# Altium schematic sheet BMS HY2120-CB.SchDoc
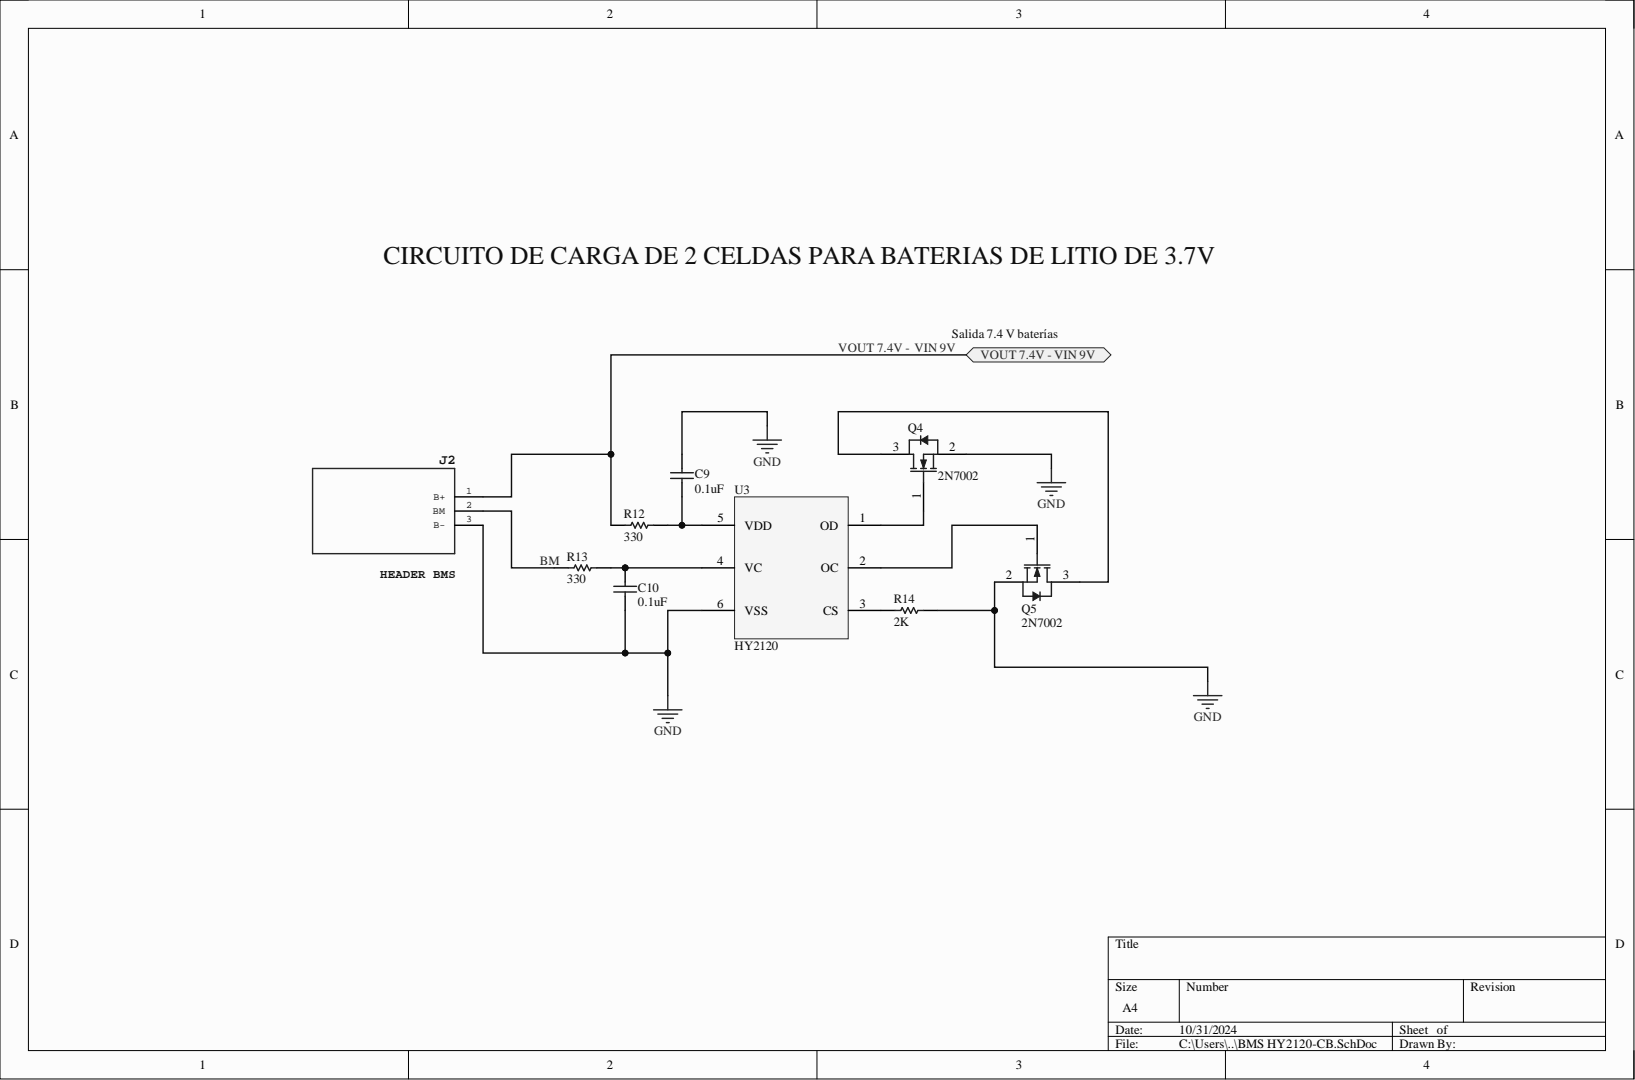
[diagram p0: BMS HY2120-CB.SchDoc]

## Parts
C9  value 0.1uF  [CC0805]  (hidden comment: Cap)
C10  value 0.1uF  [CC0805]  (hidden comment: Cap)
J2  HEADER BMS  [HEADER 3 PIN]
Q4  2N7002  [2N7002]
Q5  2N7002  [2N7002]
R12  value 330  [RES 1206]  (hidden comment: 1%)
R13  value 330  [RES 1206]  (hidden comment: 1%)
R14  value 2K  [RES 1206]  (hidden comment: 1%)
U3  HY2120  [HY2120]

## Ports
VOUT 7.4V - VIN 9V

## Nets
GND: C9.2 C10.1 J2.3 Q4.2 Q5.2 R14.2 U3.6(VSS)
BM: J2.2 R13.1
VOUT 7.4V -  VIN 9V: J2.1 R12.1 ; port VOUT 7.4V - VIN 9V
NetC9_1: C9.1 R12.2 U3.5(VDD)
NetC10_2: C10.2 R13.2 U3.4(VC)
NetQ4_1: Q4.1 U3.1(OD)
NetQ4_3: Q4.3 Q5.3
NetQ5_1: Q5.1 U3.2(OC)
NetR14_1: R14.1 U3.3(CS)

## Library data of the parts
C9 C10 = Cap_1: Capacitor; Component Kind: Standard; LatestRevisionDate: 17-Jul-2002; LatestRevisionNote: Re-released for DXP Platform.; PackageDescription: Radial Cap, Thru-Hole; 2 Leads; 0.3 in Pin Spacing; PackageReference: RAD-0.3; Published: 8-Jun-2000; Library Name: biblioteca esquematico sistema de drenaje.SchLib; Publisher: Altium Limited
J2 = HEADER BMS: DeviceName: J; DeviceSetName: SAMTEC-TSW-101-07-X-T; GateName_1: A; SymbolName_1: SAMTEC-TSW-101-07-X-TA; Component Kind: Standard; LibraryName: SAMTEC-TSW-101-07-X-T; Package: SAMTEC-TSW-101-07-X-T; Library Name: biblioteca esquematico sistema de drenaje.SchLib
Q4 Q5 = 2N7002: N-channel 60 V, 1.8 Ohm, 0.35 A STripFET(TM) II Power MOSFET, SOT23; Manufacturer: STMicroelectronics; Component Kind: Standard; ComponentLink1Description: Manufacturer URL; ComponentLink1URL: http://www.st.com/; ComponentLink2Description: Datasheet; ComponentLink2URL: http://www.st.com/st-web-ui/static/active/en/resource/technical/document/datasheet/CD00005134.pdf; DatasheetVersion: Rev. 9, 11/2008; Mounting Technology: Surface Mount; PackageDescription: 3-Pin SOT23, 3.016 x 2.8 mm Body, 0.95 mm Pitch; PackageReference: SOT-23; PartNumber: 2N7002; Library Name: biblioteca esquematico sistema de drenaje.SchLib
R12-R14 = Resistor: Component Kind: Standard; Description: Resistor; LatestRevisionDate: 17-Jul-2002; LatestRevisionNote: Re-released for DXP Platform.; PackageDescription: Axial Device, Thru-Hole; 2 Leads; 0.3 in Pin Spacing; PackageReference: AXIAL-0.3; Published: 8-Jun-2000; Library Name: biblioteca esquematico sistema de drenaje.SchLib; Publisher: Altium Limited
U3 = HY2120: BMS 2S Li-ion; Component Kind: Standard; Library Name: biblioteca esquematico sistema de drenaje.SchLib
